# Altium schematic sheet [4]Ethernet_PHY.SchDoc
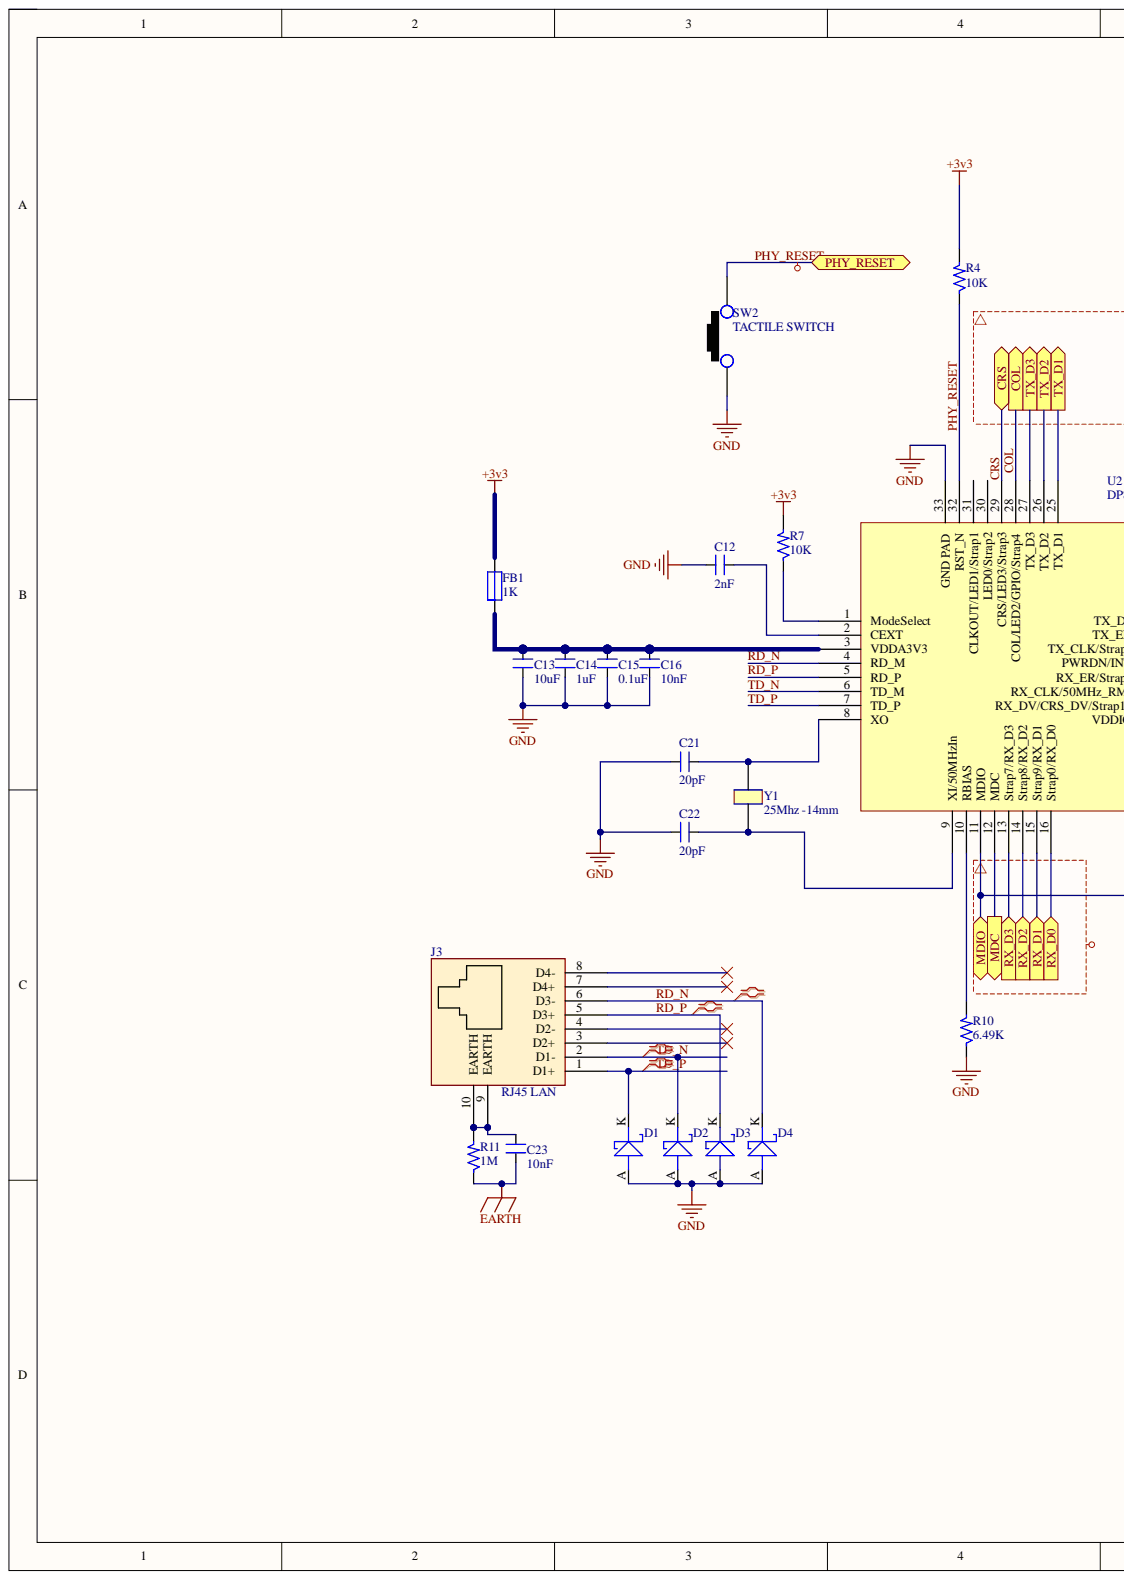
[diagram p0: [4]Ethernet_PHY.SchDoc, left]
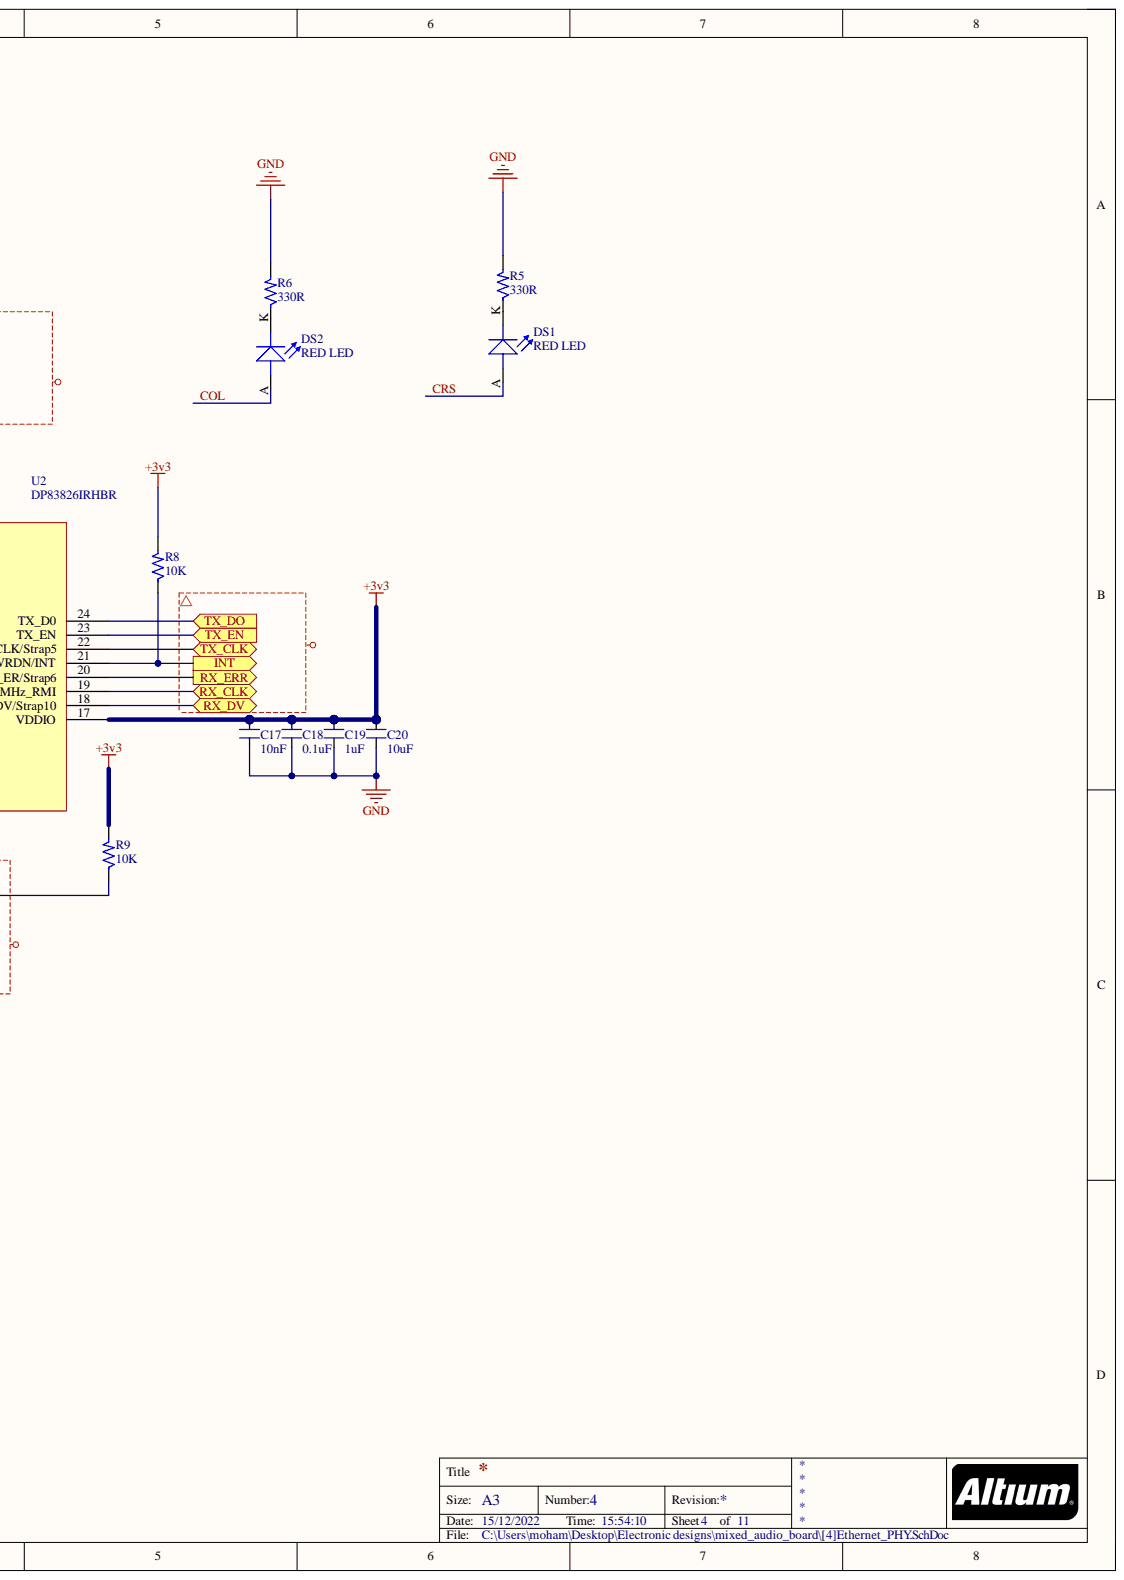
[diagram p1: [4]Ethernet_PHY.SchDoc, right]

## Parts
C12  2nF  [CAP_0805]
C13  10uF  [CAP_1206]
C14  1uF  [CAP_0805]
C15  0.1uF  [CAP_0805]
C16  10nF  [CAP_0805]
C17  10nF  [CAP_0805]
C18  0.1uF  [CAP_0805]
C19  1uF  [CAP_0805]
C20  10uF  [CAP_1206]
C21  20pF  [CAP_0805]
C22  20pF  [CAP_0805]
C23  10nF  [CAP_P196_102X287_H332_RAD_TH]
D1  ESD3V3D9-TP  [DIO_SOD-923]  (value not drawn)
D2  ESD3V3D9-TP  [DIO_SOD-923]  (value not drawn)
D3  ESD3V3D9-TP  [DIO_SOD-923]  (value not drawn)
D4  ESD3V3D9-TP  [DIO_SOD-923]  (value not drawn)
DS1  RED LED  [LED_0805]
DS2  RED LED  [LED_0805]
FB1  1K  [IND_0805]
J3  RJ45 LAN  [CON10_P106_RJ45_TH_ST]
R4  10K  [RES_0805]
R5  330R  [RES_0805]
R6  330R  [RES_0805]
R7  10K  [RES_0805]
R8  10K  [RES_0805]
R9  10K  [RES_0805]
R10  6.49K  [RES_0805]
R11  1M  [RES_0805]
SW2  TACTILE SWITCH  [SW_342_SMD]
U2  DP83826IRHBR  [VQFN32_19_218_23X9_SMD]
Y1  25Mhz -14mm  [XTAL_314_240X47_SMD]

## Ports
COL, CRS, INT, MDC, MDIO, PHY_RESET, RX_CLK, RX_D0, RX_D1, RX_D2, RX_D3, RX_DV, RX_ERR, TX_CLK, TX_D1, TX_D2, TX_D3, TX_DO, TX_EN

## Nets
+3v3: C17.2 C18.2 C19.2 C20.2 FB1.2 R4.2 R7.2 R8.2 R9.2 U2.17(VDDIO)
EARTH: C23.1 R11.1
GND: C12.1 C13.1 C14.1 C15.1 C16.1 C17.1 C18.1 C19.1 C20.1 C21.1 C22.1 D1.A D2.A D3.A D4.A R5.2 R6.2 R10.1 SW2.1 U2.33(GND_PAD)
COL: DS2.A U2.28(COL/LED2/GPIO/Strap4) ; port COL
CRS: DS1.A U2.29(CRS/LED3/Strap3) ; port CRS
INT: R8.1 U2.21(PWRDN/INT) ; port INT
MDC: U2.12(MDC) ; port MDC
MDIO: R9.1 U2.11(MDIO) ; port MDIO
PHY_RESET: R4.1 SW2.2 U2.32(RST_N) ; port PHY_RESET
RD_N: D4.K J3.6(D3-) U2.4(RD_M)
RD_P: D3.K J3.5(D3+) U2.5(RD_P)
RX_CLK: U2.19(RX_CLK/50MHz_RMI) ; port RX_CLK
RX_D0: U2.16(Strap0/RX_D0) ; port RX_D0
RX_D1: U2.15(Strap9/RX_D1) ; port RX_D1
RX_D2: U2.14(Strap8/RX_D2) ; port RX_D2
RX_D3: U2.13(Strap7/RX_D3) ; port RX_D3
RX_DV: U2.18(RX_DV/CRS_DV/Strap10) ; port RX_DV
RX_ERR: U2.20(RX_ER/Strap6) ; port RX_ERR
TD_N: D2.K J3.2(D1-) U2.6(TD_M)
TD_P: D1.K J3.1(D1+) U2.7(TD_P)
TX_CLK: U2.22(TX_CLK/Strap5) ; port TX_CLK
TX_D1: U2.25(TX_D1) ; port TX_D1
TX_D2: U2.26(TX_D2) ; port TX_D2
TX_D3: U2.27(TX_D3) ; port TX_D3
TX_DO: U2.24(TX_D0) ; port TX_DO
TX_EN: U2.23(TX_EN) ; port TX_EN
NetC12_2: C12.2 U2.2(CEXT)
NetC13_2: C13.2 C14.2 C15.2 C16.2 FB1.1 U2.3(VDDA3V3)
NetC21_2: C21.2 U2.8(XO) Y1.2
NetC22_2: C22.2 U2.9(XI/50MHzIn) Y1.1
NetC23_2: C23.2 J3.9(EARTH) J3.10(EARTH) R11.2
NetDS1_K: DS1.K R5.1
NetDS2_K: DS2.K R6.1
NetR7_1: R7.1 U2.1(ModeSelect)
NetR10_2: R10.2 U2.10(RBIAS)

Not connected: J3 3(D2+) 4(D2-) 7(D4+) 8(D4-); U2 30(LED0/Strap2) 31(CLKOUT/LED1/Strap1)

## Library data of the parts
C12 = CAP_2nF-50V-10%-0805: 2000pF ±10% 50V Ceramic Capacitor X7R 0805 (2012 Metric); Alternate Part Number-1: NA; Manufacturer: Samsung Electro-Mechanics; Manufacturer Part Number: CL21B202KBANNNC; Case/Package: 0805; Component Kind: Standard; Library Name: ESTEEMPCBLIB.IntLib; Supplier Part Number-1: 1276-2471-1-ND - Cut Tape (CT); Supplier-1: Digikey
C13 C20 = CAP_10uF-50V-10%-1206: 10µF ±10% 50V Ceramic Capacitor X7R 1206 (3216 Metric); Alternate Part Number-1: GRT31CR61H106ME01L; Manufacturer: KEMET; Manufacturer Part Number: CL31B106KBHNNNE; Case/Package: 1206; Component Kind: Standard; Library Name: ESTEEMPCBLIB.IntLib; Supplier Part Number-1: CC1206KKX5R9BB106; Supplier-1: SIRICOM
C14 C19 = CAP_1uF-50V-5%-0805: 1µF ±5% 50V Ceramic Capacitor X7R 0805 (2012 Metric); Alternate Part Number-1: 0805F105M500NT; Manufacturer: Samsung; Manufacturer Part Number: CC0805JKX7R9BB105; Case/Package: 0805; Component Kind: Standard; Library Name: ESTEEMPCBLIB.IntLib; Supplier Part Number-1: CL21B105KBFNNNE; Supplier-1: SIRICOM
C15 C18 = CAP_0.1uF-50V-5%-0805: 0.1µF ±5% 50V Ceramic Capacitor X7R 0805 (2012 Metric); Alternate Part Number-1: 0805B104M500; Manufacturer: AVX Corporation; Manufacturer Part Number: 08055C104JAT4A; Case/Package: 0805; Component Kind: Standard; Library Name: ESTEEMPCBLIB.IntLib; Supplier Part Number-1: 0805B104J500CT; Supplier-1: SIRICOM
C16 C17 = CAP_10nF-50V-10%-0805: 10000pF ±10% 50V Ceramic Capacitor X7R 0805 (2012 Metric); Alternate Part Number-1: GRM2195C1H103JA01D; Manufacturer: Samsung; Manufacturer Part Number: C0805C103K5RECAUTO; Case/Package: 0805; Component Kind: Standard; Library Name: ESTEEMPCBLIB.IntLib; Supplier Part Number-1: 0805B103K500CT; Supplier-1: SIRICOM
C21 C22 = CAP_20pF-50V-10%-0805: ±10% 50V Ceramic Capacitor C0G, NP0 0805 (2012 Metric); Alternate Part Number-1: NA; Manufacturer: AVX Corporation; Manufacturer Part Number: 08055A200KAT2A; Case/Package: 0805; Component Kind: Standard; Library Name: ESTEEMPCBLIB.IntLib; Supplier Part Number-1: 478-11887-1-ND - Cut Tape (CT); Supplier-1: Digikey
C23 = CAP_10nF-1KV-10%-RAD-TH: 10000pF ±10% 1000V (1kV) Ceramic Capacitor X7R Radial; Alternate Part Number-1: NA; Manufacturer: Yageo; Manufacturer Part Number: RDER73A103K2K1H03B; Case/Package: TH; Component Kind: Standard; Library Name: ESTEEMPCBLIB.IntLib; Supplier Part Number-1: RDER73A103K2K1H03B; Supplier-1: SP ROAD
D1-D4 = DIO-T_3.3V-8.8V-10A-SOD923: TVS DIODE 3.3V 8.8V SOD923; Alternate Part Number-1: NA; Manufacturer: Micro Commercial Co; Case/Package: SOD923; Component Kind: Standard; Library Name: ESTEEMPCBLIB.IntLib; Manufacturer Part Number: ESD3V3D9-TP; Supplier Part Number-1: ESD3V3D9-TPMSTR-ND; Supplier-1: Digikey
DS1 DS2 = LED_RED-2V-20mA-0805: Red 631nm LED Indication - Discrete 2V 0805 (2012 Metric); Alternate Part Number-1: NA; Manufacturer: Vishay Lite-On; Manufacturer Part Number: LTST-C171KRKT; Case/Package: 0805; Component Kind: Standard; Library Name: ESTEEMPCBLIB.IntLib; Supplier Part Number-1: LTST-C171KRKT; Supplier-1: SP ROAD
FB1 = FB_1K-100MHZ-1.6A-0805: 1 kOhms @ 100MHz 1 Power Line Ferrite Bead 0805 (2012 Metric) 1.6A; Alternate Part Number-1: NA; Manufacturer: Laird; Manufacturer Part Number: BLM21SP102SH1D; Case/Package: 0805; Component Kind: Standard; Library Name: ESTEEMPCBLIB.IntLib; Supplier Part Number-1: ACMS201209A102; Supplier-1: SIRICOM
J3 = CON-SKT-RJ_8C8P-RA-TH: 8 Pins, 2 Row 2.54mm Pitch, right angle Connector, male; Alternate Part Number-1: NA; Manufacturer: Assmann; Manufacturer Part Number: A-2004-2-4-LPS-N-R; Case/Package: TH-CON; Component Kind: Standard; Library Name: ESTEEMPCBLIB.IntLib; Supplier Part Number-1: A-2004-2-4-LPS-N-R; Supplier-1: SP ROAD
R4 R7-R9 = RES_10K-0.125W-1%-0805: 10 kOhms ±1% 0.125W, 1/8W Chip Resistor 0805 (2012 Metric) Automotive AEC-Q200 Thick Film; Alternate Part Number-1: WR08X1002FTL; Manufacturer: CTS; Case/Package: 0805; Component Kind: Standard; Library Name: ESTEEMPCBLIB.IntLib; Manufacturer Part Number: WR08X1002FTL; Supplier Part Number-1: WR08X1002FTL; Supplier-1: SIRICOM
R5 R6 = RES_330R-0.125W-1%-0805: 330 Ohms ±1% 0.125W, 1/8W Chip Resistor 0805 (2012 Metric) Automotive AEC-Q200 Thick Film; Alternate Part Number-1: NA; Manufacturer: Walsin; Manufacturer Part Number: WR08X3300FTL; Case/Package: 0805; Component Kind: Standard; Library Name: ESTEEMPCBLIB.IntLib; Supplier Part Number-1: WR08X3300FTL; Supplier-1: SIRICOM
R10 = RES_6.49K-0.125W-1%-0805: 6.49 kOhms ±1% 0.125W, 1/8W Chip Resistor 0805 (2012 Metric) Moisture Resistant Thick Film; Alternate Part Number-1: NA; Manufacturer: Stackpole Electronics; Manufacturer Part Number: RC0805FR-076K49L; Case/Package: 0805; Component Kind: Standard; Library Name: ESTEEMPCBLIB.IntLib; Supplier Part Number-1: WRO8X6491FTL; Supplier-1: SIRICOM
R11 = RES_1M-0.125W-1%-0805: 1 MOhms ±1% 0.125W, 1/8W Chip Resistor 0805 (2012 Metric) Automotive AEC-Q200 Thick Film; Alternate Part Number-1: 0805W8F1004T5E; Manufacturer: Walsin; Manufacturer Part Number: WR08X1004FTL; Case/Package: 0805; Component Kind: Standard; Library Name: ESTEEMPCBLIB.IntLib; Supplier Part Number-1: WR08X1004FTL; Supplier-1: SIRICOM
SW2 = SW1_RS-282G05A3-SMRT-SMD: Tactile Switch SPST-NO Top Actuated Surface Mount; Alternate Part Number-1: NA; Manufacturer: ITT C&K; Case/Package: SMD; Component Kind: Standard; Library Name: ESTEEMPCBLIB.IntLib; Manufacturer Part Number: RS-282G05A3-SMRT; Supplier Part Number-1: RS-282G05A3-SMRT; Supplier-1: SP ROAD
U2 = IC_DP83826IRHBR: Ethernet ICs Low latency 10/100-Mbps PHY, MII interface and enhanced mode with an industrial temperature range 32-VQFN -40 to 85; Alternate Part Number-1: NA; Manufacturer: Texas Instruments; Case/Package: 32-VQFN; Component Kind: Standard; Library Name: ESTEEMPCBLIB.IntLib; Manufacturer Part Number: DP83826IRHBR; Supplier Part Number-1: 595-DP83826IRHBR; Supplier-1: Mouser
Y1 = XTAL_25Mhz-FOXSDLF/250F-20: 25MHz ±30ppm Crystal 20pF 30 Ohms HC-49/US; Alternate Part Number-1: NA; Manufacturer: Abracon; Case/Package: SMD; Component Kind: Standard; Library Name: ESTEEMPCBLIB.IntLib; Manufacturer Part Number: FOXSDLF/250F-20; Supplier Part Number-1: FOXSDLF/250F-20; Supplier-1: MOUSER
